# Altium schematic sheet 4-AD-DA.SchDoc(4-AD-DA)
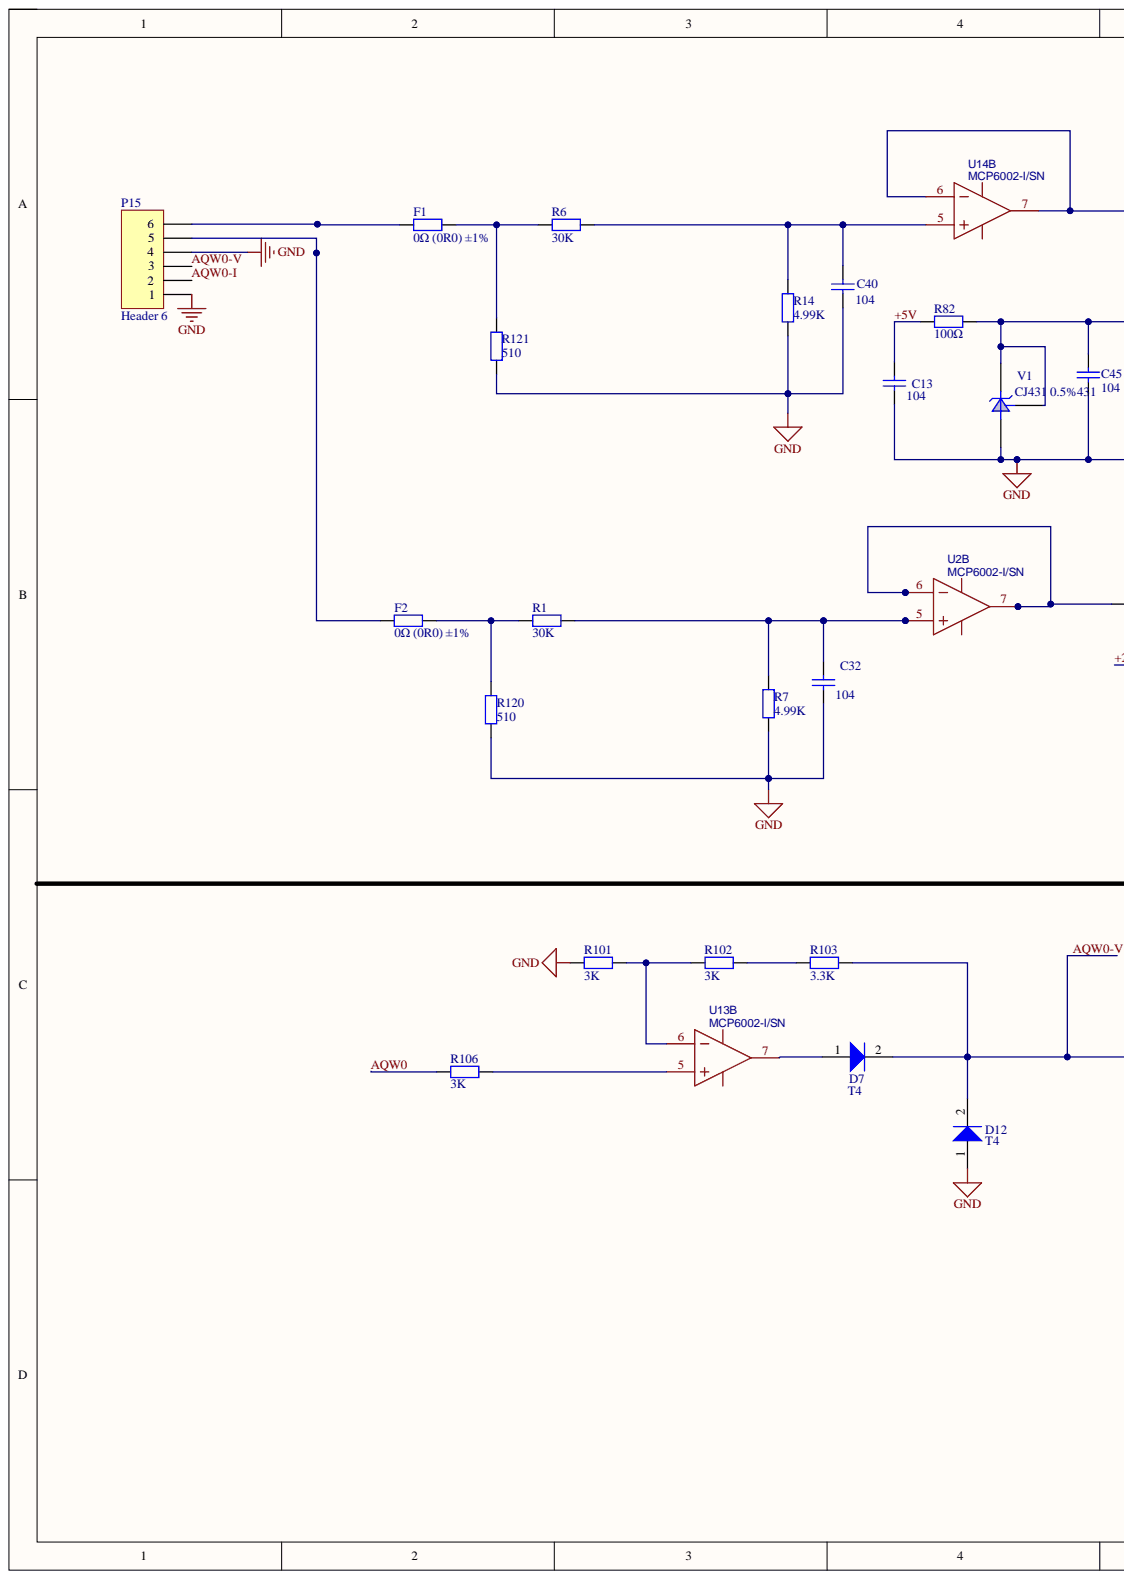
[diagram p0: 4-AD-DA.SchDoc, left]
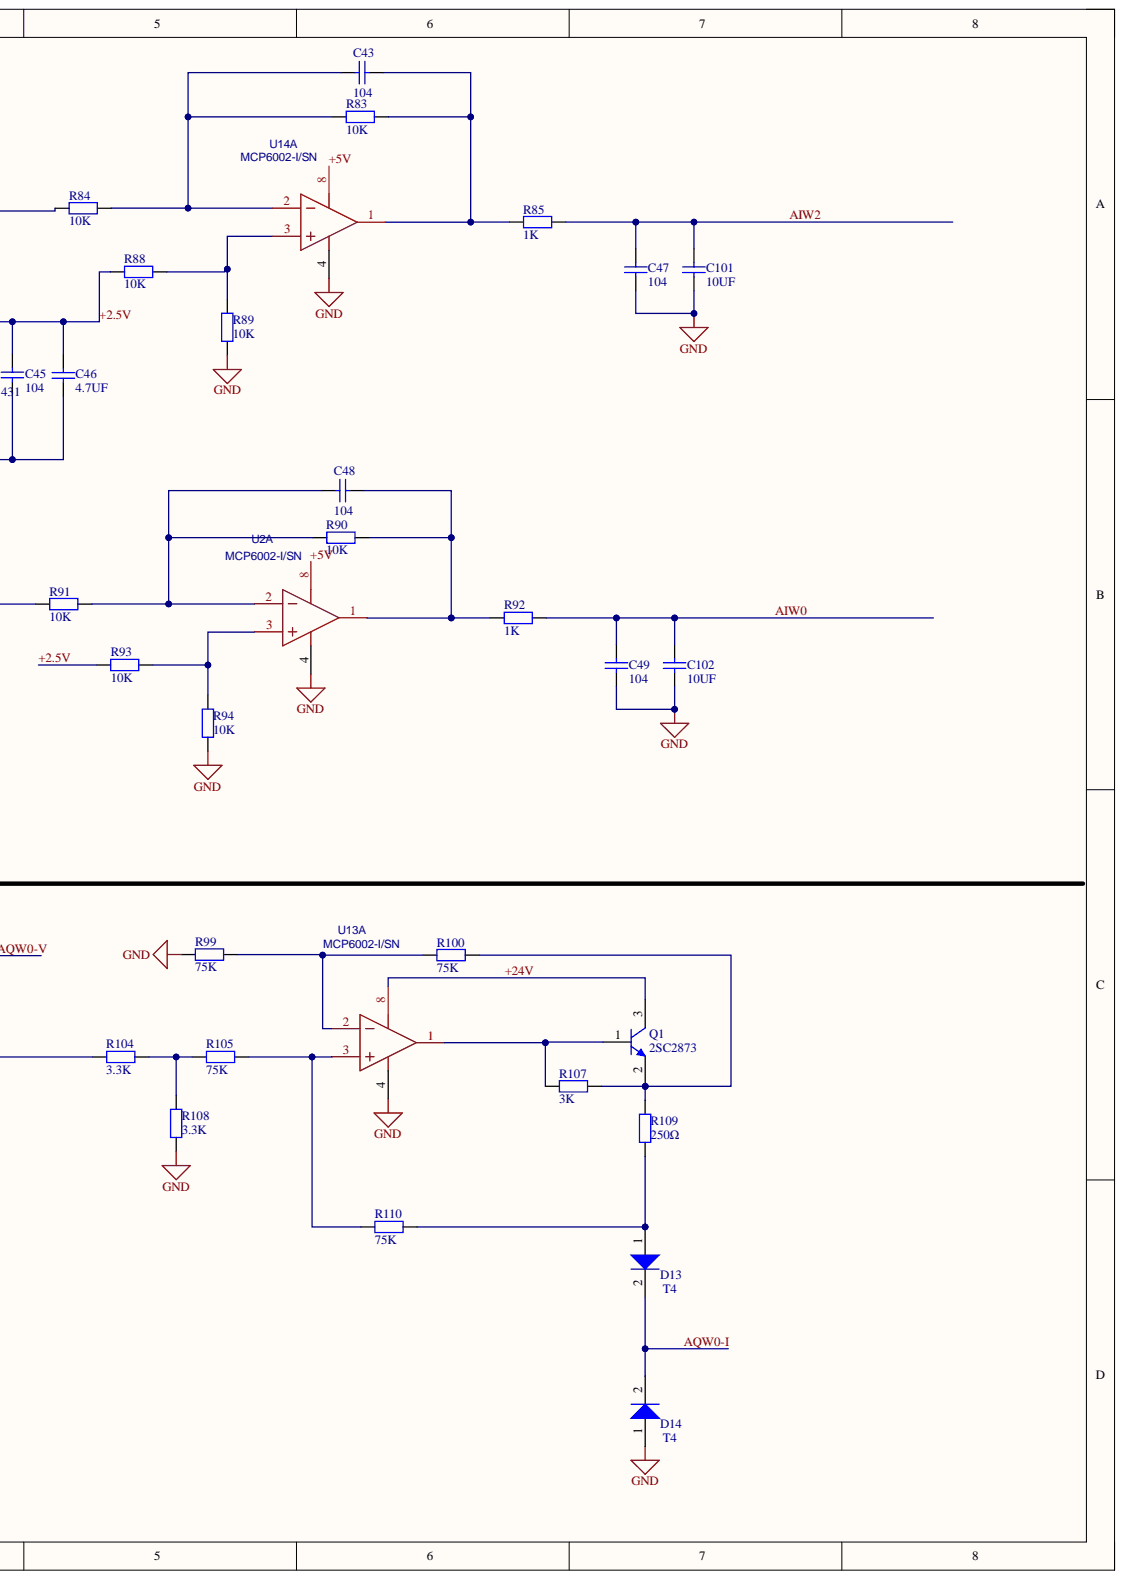
[diagram p1: 4-AD-DA.SchDoc, right]

## Parts
C13  104  [0603_C]
C32  104  [0603_C]
C40  104  [0603_C]
C43  104  [0603_C]
C45  104  [0603_C]
C46  4.7UF  value 104  [0603_C]
C47  104  [0603_C]
C48  104  [0603_C]
C49  104  [0603_C]
C101  10UF  [1206_C]
C102  10UF  [1206_C]
D7  T4  [SOD-123]
D12  T4  [SOD-123]
D13  T4  [SOD-123]
D14  T4  [SOD-123]
F1  0Ω (0R0) ±1%  [0603_R]
F2  0Ω (0R0) ±1%  [0603_R]
P15  Header 6  [HDR1X6]
Q1  2SC2873  [SOT-23-3L]
R1  30K  [0603_R]
R6  30K  [0603_R]
R7  4.99K  [0603_R]
R14  4.99K  [0603_R]
R82  100Ω  [0603_R]
R83  10K  [0603_R]
R84  10K  [0603_R]
R85  1K  [0603_R]
R88  10K  [0603_R]
R89  10K  [0603_R]
R90  10K  [0603_R]
R91  10K  [0603_R]
R92  1K  [0603_R]
R93  10K  [0603_R]
R94  10K  [0603_R]
R99  75K  [0603_R]
R100  75K  [0603_R]
R101  3K  [0603_R]
R102  3K  [0603_R]
R103  3.3K  [1206_R]
R104  3.3K  [0603_R]
R105  75K  [0603_R]
R106  3K  [0603_R]
R107  3K  [0603_R]
R108  3.3K  [0603_R]
R109  250Ω  [0603_R]
R110  75K  [0603_R]
R120  510  [0603_R]
R121  510  [0603_R]
U2A  MCP6002-I/SN  [SOIC-8_L4.9-W3.9-P1.27-LS6.0-BL]
U2B  MCP6002-I/SN  [SOIC-8_L4.9-W3.9-P1.27-LS6.0-BL]
U13A  MCP6002-I/SN  [SOIC-8_L4.9-W3.9-P1.27-LS6.0-BL]
U13B  MCP6002-I/SN  [SOIC-8_L4.9-W3.9-P1.27-LS6.0-BL]
U14A  MCP6002-I/SN  [SOIC-8_L4.9-W3.9-P1.27-LS6.0-BL]
U14B  MCP6002-I/SN  [SOIC-8_L4.9-W3.9-P1.27-LS6.0-BL]
V1  CJ431 0.5%431  [SOT-23(SOT-23-3)]

## Nets
GND: C13.1 C32.1 C40.1 C45.1 C46.1 C47.1 C49.1 C101.1 C102.1 D12.1 D14.1 P15.1 P15.4 R7.1 R14.1 R89.1 R94.1 R99.2 R101.1 R108.1 R120.1 R121.1 U2A.4 U13A.4 U14A.4 V1.3
+2.5V: C45.2 C46.2 R82.1 R88.1 R93.1 V1.1 V1.2
+5V: C13.2 R82.2 U2A.8 U14A.8
+24V: Q1.3 U13A.8
AIW0: C49.2 C102.2 R92.1
AIW2: C47.2 C101.2 R85.1
AQW0: R106.1
AQW0-I: D13.2 D14.2 P15.2
AQW0-V: D7.2 D12.2 P15.3 R103.1 R104.1
NetC32_2: C32.2 R1.2 R7.2 U2B.5
NetC40_2: C40.2 R6.2 R14.2 U14B.5
NetC43_1: C43.1 R83.1 R85.2 U14A.1
NetC43_2: C43.2 R83.2 R84.2 U14A.2
NetC48_1: C48.1 R90.1 R92.2 U2A.1
NetC48_2: C48.2 R90.2 R91.2 U2A.2
NetD7_1: D7.1 U13B.7
NetD13_1: D13.1 R109.2 R110.1
NetF1_1: F1.1 P15.6
NetF1_2: F1.2 R6.1 R121.2
NetF2_1: F2.1 P15.5
NetF2_2: F2.2 R1.1 R120.2
NetQ1_1: Q1.1 R107.2 U13A.1
NetQ1_2: Q1.2 R100.1 R107.1 R109.1
NetR84_1: R84.1 U14B.6 U14B.7
NetR88_2: R88.2 R89.2 U14A.3
NetR91_1: R91.1 U2B.6 U2B.7
NetR93_2: R93.2 R94.2 U2A.3
NetR99_1: R99.1 R100.2 U13A.2
NetR101_2: R101.2 R102.2 U13B.6
NetR102_1: R102.1 R103.2
NetR104_2: R104.2 R105.2 R108.2
NetR105_1: R105.1 R110.2 U13A.3
NetR106_2: R106.2 U13B.5

## Library data of the parts
C13 C32 C40 C43 C45-C49 = Cap_0603: 0603贴片电容; Component Kind: Standard; Library Name: 02-Miscellaneous_Devices_ZSF_Library.IntLib; Package: 0805; 常见贴片电容尺寸图: https://wenku.baidu.com/view/21d5206100f69e3143323968011ca300a6c3f6b2.html
  C13 C32 C40 C43 C45 C47-C49: Value: 104
C101 C102 = Cap_1206: 1206贴片电容; Value: 104; Component Kind: Standard; Library Name: 02-Miscellaneous_Devices_ZSF_Library.IntLib; Package: 0805; 常见贴片电容尺寸图: https://wenku.baidu.com/view/21d5206100f69e3143323968011ca300a6c3f6b2.html
D7 D12-D14 = Diode_SOD-123: 二极管长2.7*宽1.6*高1.1 mm; Component Kind: Standard; Library Name: 02-Miscellaneous_Devices_ZSF_Library.IntLib; Revision: July-2002: Re-released for DXP Platform.; 封装区别: http://jcet.svell.cn/Product/Lists/117/
F1 F2 R1 R6 R7 R14 R82-R85 R88-R94 R99-R102 R104-R110 R120 R121 = Res_0603: 贴片电阻; Component Kind: Standard; ComponentLink1Description: 供应商链接; ComponentLink1URL: http://www.szlcsc.com/product/details_21903.html; Library Name: 02-Miscellaneous_Devices_ZSF_Library.IntLib; Package: 0603; Supplier: LC; SuppliersPartNumber: C21189
P15 = Header 6: Header, 6-Pin; Component Kind: Standard; LatestRevisionDate: 17-Jul-2002; LatestRevisionNote: Re-released for DXP Platform.; Library Name: Miscellaneous Connectors.IntLib; Signal Integrity: Connector; Publisher: Altium Limited
Q1 = 9013_SOT-23: NPN型小功率三极管; Component Kind: Standard; HelpURL: http://pdf1.alldatasheetcn.com/datasheet-pdf/view/33678/WINGS/9013.html; Library Name: 02-Miscellaneous_Devices_ZSF_Library.IntLib
R103 = Res_1206: 1206贴片电阻; Component Kind: Standard; ComponentLink1Description: 供应商链接; ComponentLink1URL: http://www.szlcsc.com/product/details_18673.html; Library Name: 02-Miscellaneous_Devices_ZSF_Library.IntLib; Package: 1206; Supplier: LC; SuppliersPartNumber: C17985
U2A U2B U13A U13B U14A U14B = MCP6002-I/SN: BOM_Manufacturer: MICROCHIP; subpart_no: 2; BOM_Manufacturer Part: MCP6002-I/SN; BOM_Supplier: LCSC; BOM_Supplier Part: C116706; Component Kind: Standard; Operating Temperature: -40°C ~ 85°C; spicePre: U; spiceSymbolName: MCP6002-I/SN
V1 = CJ431 0.5%431: 电压基准; Component Kind: Standard; ComponentLink1Description: 供应商链接; ComponentLink1URL: http://www.szlcsc.com/product/details_3500.html; Library Name: 02-Miscellaneous_Devices_ZSF_Library.IntLib; Package: SOT-23; Supplier: LC; SuppliersPartNumber: C3113
